# Altium schematic sheet Other_Componentt.SchDoc(Other_Componentt)
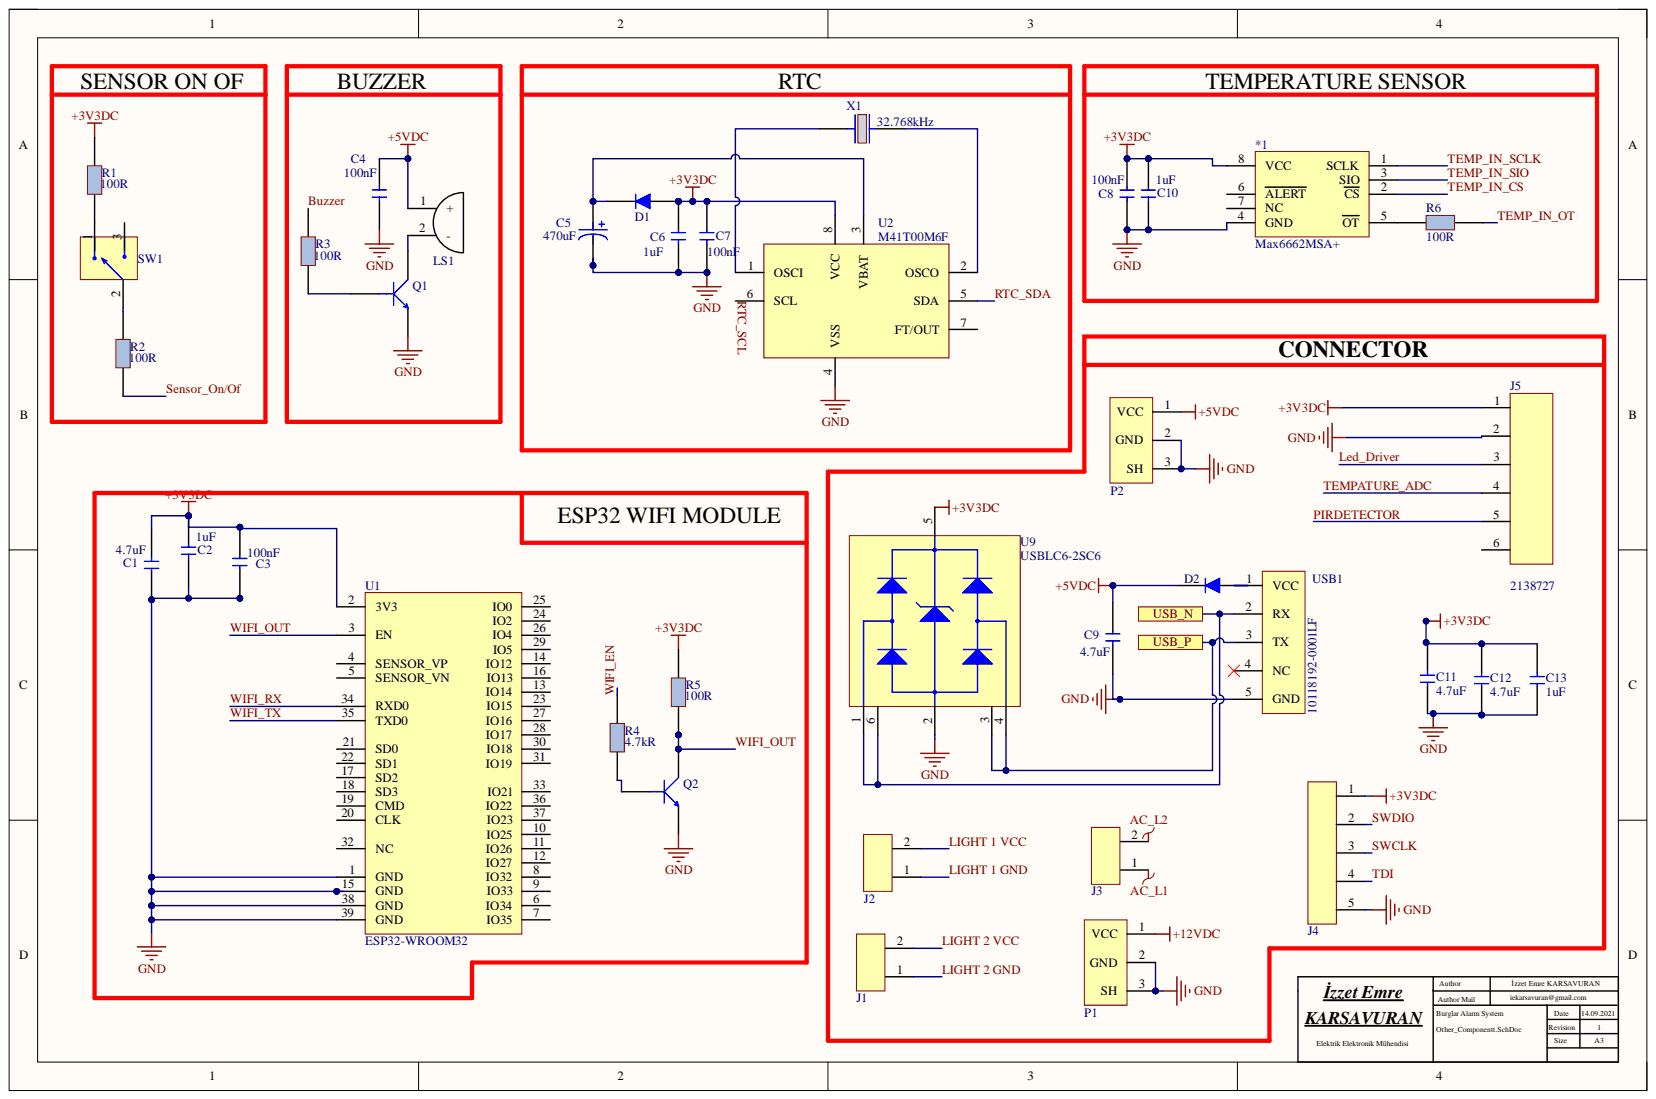
[diagram p0: Other_Componentt.SchDoc]

## Parts
*1  Max6662MSA+  [SOIC8]
C1  Capacitance: 4.7uF  [CAP_0805]  (hidden comment: CL21A475KAQNNNE)
C2  Capacitance: 1uF  [CAP_0805]  (hidden comment: CL21B105KBFNNNE)
C3  Capacitance: 100nF  [CAP_0805]  (hidden comment: CL21B104KBCNNNC)
C4  Capacitance: 100nF  [CAP_0805]  (hidden comment: CL21B104KBCNNNC)
C5  Capacitance: 470uF  [CAP_RADIAL_D10_P5]  (hidden comment: ESH477M050AH4AA)
C6  Capacitance: 1uF  [CAP_0805]  (hidden comment: CL21B105KBFNNNE)
C7  Capacitance: 100nF  [CAP_0805]  (hidden comment: CL21B104KBCNNNC)
C8  Capacitance: 100nF  [CAP_0805]  (hidden comment: CL21B104KBCNNNC)
C9  Capacitance: 4.7uF  [CAP_0805]  (hidden comment: CL21A475KAQNNNE)
C10  Capacitance: 1uF  [CAP_0805]  (hidden comment: CL21B105KBFNNNE)
C11  Capacitance: 4.7uF  [CAP_0805]  (hidden comment: CL21A475KAQNNNE)
C12  Capacitance: 4.7uF  [CAP_0805]  (hidden comment: CL21A475KAQNNNE)
C13  Capacitance: 1uF  [CAP_0805]  (hidden comment: CL21B105KBFNNNE)
D1  1N4148WS  [SOT23-F]  (value not drawn)
D2  1N4148WS  [SOT23-F]  (value not drawn)
J1  TBP01R1-508-02BE  [TBP01R1-508]  (value not drawn)
J2  TBP01R1-508-02BE  [TBP01R1-508]  (value not drawn)
J3  TBP01R1-508-02BE  [TBP01R1-508]  (value not drawn)
J4  SMH-105-02-L-S  [PINHEADER1X5_RA]  (value not drawn)
J5  Supplier Part Number 1: 2138727  [64800611622]  (hidden comment: 64800611622)
LS1  CMI-9605IC-0580T  [CMI-9605IC-0580T]  (value not drawn)
P1  PJ-037AH-SMT-TR  [JACK]  (value not drawn)
P2  PJ-037AH-SMT-TR  [JACK]  (value not drawn)
Q1  MMBT3904-7-F  [SOT23-F]  (value not drawn)
Q2  MMBT3904-7-F  [SOT23-F]  (value not drawn)
R1  Resistance: 100R  [RES_0603]  (hidden comment: RC0603JR-07100RL)
R2  Resistance: 100R  [RES_0603]  (hidden comment: RC0603JR-07100RL)
R3  Resistance: 100R  [RES_0603]  (hidden comment: RC0603JR-07100RL)
R4  Resistance: 4.7kR  [RES_0603]  (hidden comment: RC0603JR-074K7L)
R5  Resistance: 100R  [RES_0603]  (hidden comment: RC0603JR-07100RL)
R6  Resistance: 100R  [RES_0603]  (hidden comment: RC0603JR-07100RL)
SW1  EG1215AA  [EG1215AA]  (value not drawn)
U1  ESP32-WROOM32  [ESP-32]
U2  M41T00M6F  [SOIC8]
U9  USBLC6-2SC6  [SOT23-6L]
USB1  10118192-0001LF  [Micro_Usb_B]
X1  Frequency: 32.768kHz  [ABS05]  (hidden comment: ABS05-32.768KHZ-T)

## Ports
USB_N, USB_P

## Nets
+3V3DC: *1.8(VCC) C1.2 C2.1 C3.1 C6.1 C7.1 C8.1 C10.1 C11.2 C12.2 C13.2 D1.2 J4.1 J5.1 R1.2 R5.2 U1.2(3V3) U2.8(VCC) U9.5
+5VDC: C4.1 C9.1 D2.1 LS1.1 P2.1
+12VDC: P1.1
AC_L1: J3.1
AC_L2: J3.2
GND: *1.4(GND) C1.1 C2.2 C3.2 C4.2 C5.2 C6.2 C7.2 C8.2 C9.2 C10.2 C11.1 C12.1 C13.1 J4.5 J5.2 P1.2 P1.3 P2.2 P2.3 Q1.2 Q2.2 U1.1(GND) U1.15(GND) U1.38(GND) U1.39(GND) U2.4(VSS) U9.2 USB1.5(GND)
Buzzer: R3.2
Led_Driver: J5.3
LIGHT 1 GND: J2.1
LIGHT 1 VCC: J2.2
LIGHT 2 GND: J1.1
LIGHT 2 VCC: J1.2
PIRDETECTOR: J5.5
RTC_SCL: U2.6(SCL)
RTC_SDA: U2.5(SDA)
Sensor_On/Of: R2.1
SWCLK: J4.3
SWDIO: J4.2
TDI: J4.4
TEMP_IN_CS: *1.2(CS)
TEMP_IN_OT: R6.1
TEMP_IN_SCLK: *1.1(SCLK)
TEMP_IN_SIO: *1.3(SIO)
TEMPATURE_ADC: J5.4
USB_N: U9.1 U9.6 USB1.2(RX) ; port USB_N
USB_P: U9.3 U9.4 USB1.3(TX) ; port USB_P
WIFI_EN: R4.2
WIFI_OUT: Q2.3 R5.1 U1.3(EN)
WIFI_RX: U1.34(RXD0)
WIFI_TX: U1.35(TXD0)
Net*1_5: *1.5(OT) R6.2
NetC5_1: C5.1 D1.1 U2.3(VBAT)
NetD2_2: D2.2 USB1.1(VCC)
NetLS1_2: LS1.2 Q1.3
NetQ1_1: Q1.1 R3.1
NetQ2_1: Q2.1 R4.1
NetR1_1: R1.1 SW1.1
NetR2_2: R2.2 SW1.2
NetU2_1: U2.1(OSCI) X1.1
NetU2_2: U2.2(OSCO) X1.2

Not connected: *1 6(ALERT) 7(NC); J5 6; SW1 3; U1 4(SENSOR_VP) 5(SENSOR_VN) 6(IO34) 7(IO35) 8(IO32) 9(IO33) 10(IO25) 11(IO26) 12(IO27) 13(IO14) 14(IO12) 16(IO13) 17(SD2) 18(SD3) 19(CMD) 20(CLK) 21(SD0) 22(SD1) 23(IO15) 24(IO2) 25(IO0) 26(IO4) 27(IO16) 28(IO17) 29(IO5) 30(IO18) 31(IO19) 32(NC) 33(IO21) 36(IO22) 37(IO23); U2 7(FT/OUT); USB1 4(NC)

## Library data of the parts
*1 = Max6662MSA+: Temp Sensor Digital Serial (3-Wire, SPI) 8-Pin SOIC N Tube; Manufacturer: Maxim; Designed By: İzzet Emre KARSAVURAN; Max Power Dissipation: 471mW; Max Supply Voltage: 5.5V; Min Supply Voltage: 3V; Number of Bits: 12; Operating Supply Current: 600uA; Output Type: Digital,SPI; Resolution: 12b; Case/Package: SOIC; Category: Temperature and Humidity Sensors; Component Kind: Standard; ComponentLink1Description: https://datasheet.ciiva.com/5299/max6662-89142-5299456.pdf?src-supplier=Mouser; ComponentLink1URL: https://datasheet.ciiva.com/5299/max6662-89142-5299456.pdf?src-supplier=Mouser; ComponentLink2Description: https://datasheet.ciiva.com/16635/max6662-16635842.pdf?src-supplier=Avnet+Europe; ComponentLink2URL: https://datasheet.ciiva.com/16635/max6662-16635842.pdf?src-supplier=Avnet+Europe; ComponentLink3Description: https://datasheet.ciiva.com/3277/max6662-3277979.pdf?src-supplier=Avnet; ComponentLink3URL: https://datasheet.ciiva.com/3277/max6662-3277979.pdf?src-supplier=Avnet; ComponentLink4Description: https://datasheet.ciiva.com/2003/max6662-2003390.pdf?src-supplier=Digi-Key; ComponentLink4URL: https://datasheet.ciiva.com/2003/max6662-2003390.pdf?src-supplier=Digi-Key; Height: 1.5mm; Lead Free: Lead Free; Length: 5mm; Manufacturer Part Number: MAX6662MSA+; Max Operating Temperature: 150°C; Max Supply Voltage (DC): 5.5V; Min Operating Temperature: -55°C; Min Supply Voltage (DC): 3V; Number of Pins: 8; Package: SOIC8; Package Quantity: 100; Packaging: Rail/Tube; Pricing 1: 1=3,20000, 10=2,87000, 25=2,71360, 100=2,35170, 500=2,00196, 1000=1,78220 (USD); Radiation Hardening: No; REACH SVHC: Unknown; RoHS: Yes; RoHS Compliant: Yes; Series: MAX6662; Stock 1: 649; Supplier 1: Digi-Key; Supplier Part Number 1: MAX6662MSA+-ND; Width: 4mm; Interface: SPI; Library Name: burglar_alarm_system_Library.IntLib
C1 C9 C11 C12 = CAP CER 4.7uF: SAMSUNG ELECTRO-MECHANICS - CL21A475KAQNNNE - SMD Multilayer Ceramic Capacitor, 4.7 µF, 25 V, 0805 [2012 Metric], ± 10%, X5R, CL Series; Designed By: İzzet Emre KARSAVURAN; Voltage Rating: 25V; Case Code (Metric): 2012; Case Code (Imperial): 0805; Case/Package: 0805; Category: Ceramic Capacitors; Component Kind: Standard; ComponentLink1Description: https://datasheet.ciiva.com/25798/cl21a475kaqnnne-25798882.pdf?src-supplier=Digi-Key; ComponentLink1URL: https://datasheet.ciiva.com/25798/cl21a475kaqnnne-25798882.pdf?src-supplier=Digi-Key; Construction: Flat; Depth: 1.25mm; Dielectric: X5R; Height: 1.25mm; Lead Free: Lead Free; Length: 2mm; Manufacturer: Samsung; Manufacturer Part Number: CL21A475KAQNNNE; Max Operating Temperature: 85°C; Min Operating Temperature: -55°C; Mount: Surface Mount; Package Quantity: 1; Packaging: Tape and Reel; Pricing 1: 1=0,12000, 10=0,08300, 50=0,04720, 100=0,03910, 500=0,02742, 1000=0,02271 (USD); Radiation Hardening: No; RoHS: Yes; RoHS Compliant: Yes; Series: CL; Stock 1: 340871; Supplier 1: Digi-Key; Supplier Part Number 1: 1276-1244-1-ND; Thickness: 0.055inch; Tolerance: 10%; Voltage Rating (DC): 25V; Width: 0.049inch; Library Name: burglar_alarm_system_Library.IntLib
C2 C6 C10 C13 = CAP CER 1uF: SAMSUNG ELECTRO-MECHANICS - CL21B105KBFNNNE - SMD Multilayer Ceramic Capacitor, 1 µF, 50 V, 0805 [2012 Metric], ± 10%, X7R, CL Series; Designed By: İzzet Emre KARSAVURAN; Voltage: 50V; Case Code (Metric): 2012; Case Code (Imperial): 0805; Case/Package: 0805; Category: Ceramic Capacitors; Component Kind: Standard; ComponentLink1Description: https://datasheet.ciiva.com/25800/cl21b105kbfnnne-25800291.pdf?src-supplier=Digi-Key; ComponentLink1URL: https://datasheet.ciiva.com/25800/cl21b105kbfnnne-25800291.pdf?src-supplier=Digi-Key; ComponentLink2Description: https://datasheet.ciiva.com/16449/cl21b105kbfnnne-16449003.pdf?src-supplier=Future+Electronics; ComponentLink2URL: https://datasheet.ciiva.com/16449/cl21b105kbfnnne-16449003.pdf?src-supplier=Future+Electronics; ComponentLink3Description: https://datasheet.ciiva.com/26838/getdatasheetpartid-354075-26838668.pdf?src-supplier=Verical; ComponentLink3URL: https://datasheet.ciiva.com/26838/getdatasheetpartid-354075-26838668.pdf?src-supplier=Verical; Construction: Flat; Depth: 1.25mm; Dielectric: X7R; Height: 1.25mm; Length: 2mm; Manufacturer: Samsung; Manufacturer Part Number: CL21B105KBFNNNE; Max Operating Temperature: 125°C; Min Operating Temperature: -55°C; Mount: Surface Mount; Package Quantity: 1; Packaging: Tape and Reel; Pricing 1: 1=0,13000, 10=0,09000, 50=0,05120, 100=0,04250, 500=0,02978, 1000=0,02465 (USD); Radiation Hardening: No; RoHS: Yes; RoHS Compliant: Yes; Series: CL; Supplier 1: Digi-Key; Supplier Part Number 1: 1276-1029-6-ND; Thickness: 0.053inch; Tolerance: 10%; Voltage Rating: 50V; Width: 0.049inch; Library Name: burglar_alarm_system_Library.IntLib
C3 C4 C7 C8 = CAP CER 100nF-0803: Samsung Electro-Mechanics 100nF Multilayer Ceramic Capacitor (MLCC) 50 V 10% X7R dielectric CL max op. temp. +125C; Designed By: İzzet Emre KARSAVURAN; Voltage: 50V; Case Code (Metric): 2012; Case Code (Imperial): 0805; Case/Package: 0805; Category: Ceramic Capacitors; Component Kind: Standard; ComponentLink1Description: https://datasheet.ciiva.com/25710/cl21b104kbcnnnc-25710182.pdf?src-supplier=Digi-Key; ComponentLink1URL: https://datasheet.ciiva.com/25710/cl21b104kbcnnnc-25710182.pdf?src-supplier=Digi-Key; ComponentLink2Description: https://datasheet.ciiva.com/29199/454152-da-01-en-vs-kondensator-0805-29199814.pdf?src-supplier=Conrad; ComponentLink2URL: https://datasheet.ciiva.com/29199/454152-da-01-en-vs-kondensator-0805-29199814.pdf?src-supplier=Conrad; Construction: Flat; Contact Plating: Tin; Depth: 1.25mm; Dielectric: X7R; Height: 0.85mm; Lead Free: Lead Free; Length: 2mm; Manufacturer: Samsung; Manufacturer Part Number: CL21B104KBCNNNC; Material: Ceramic; Max Operating Temperature: 125°C; Min Operating Temperature: -55°C; Mount: Surface Mount; Packaging: Tape and Reel; Pricing 1: 1=0,10000, 10=0,05000, 50=0,02740, 100=0,02240, 500=0,01598, 1000=0,01256 (USD); Radiation Hardening: No; RoHS: Yes; RoHS Compliant: Yes; Series: CL; Supplier 1: Digi-Key; Supplier Part Number 1: 1276-1003-6-ND; Thickness: 0.037inch; Tolerance: 10%; Voltage Rating: 50V; Voltage Rating (DC): 50V; Width: 0.049inch; Library Name: burglar_alarm_system_Library.IntLib
C5 = CAP ALU ELEC 470uF 50V: Cap, 470UF, 50V, Alu Elec, Radial; Manufacturer: KEMET; Designed By: İzzet Emre KARSAVURAN; Life (Hours): 2000Hour; Polarity: Polar; Tolerance: 20%; Case/Package: Radial; Component Kind: Standard; ComponentLink1Description: https://api.kemet.com/component-edge/download/datasheet/ESH477M050AH4AA.pdf?src-supplier=Digi-Key; ComponentLink1URL: https://api.kemet.com/component-edge/download/datasheet/ESH477M050AH4AA.pdf?src-supplier=Digi-Key; Manufacturer Part Number: ESH477M050AH4AA; Max Operating Temperature: 105°C; Min Operating Temperature: -40°C; Mount: Through Hole; Packaging: Bulk; Pricing 1: 1=0,59000, 10=0,41700, 50=0,31540, 100=0,27470, 500=0,20350, 1000=0,17298, 2500=0,16280, 5000=0,15262 (USD); RoHS: Yes; RoHS Compliant: No; Supplier 1: Digi-Key; Supplier Part Number 1: 399-15683-ND; Voltage Rating: 50V; Library Name: burglar_alarm_system_Library.IntLib
D1 D2 = 1N4148WS: ON SEMICONDUCTOR - 1N4148WS - Small Signal Diode, Single, 75 V, 150 mA, 1 V, 4 ns; Manufacturer: ON Semiconductor / Fairchild; Average Rectified Current: 150mA; Designed By: İzzet Emre KARSAVURAN; Forward Voltage: 1V; Max Forward Surge Current (Ifsm): 2A; Max Repetitive Reverse Voltage (Vrrm): 75V; Max Reverse Current: 5uA; Power Dissipation: 200mW; Recovery Time: 4ns; Case/Package: SOC323F; Category: Rectifier Diodes; Component Kind: Standard; ComponentLink1Description: https://datasheet.ciiva.com/25968/1n914bws-25968945.pdf?src-supplier=Avnet+Europe; ComponentLink1URL: https://datasheet.ciiva.com/25968/1n914bws-25968945.pdf?src-supplier=Avnet+Europe; ComponentLink2Description: https://datasheet.ciiva.com/30479/0900766b810e1cd3-30479206.pdf?src-supplier=RSComponents; ComponentLink2URL: https://datasheet.ciiva.com/30479/0900766b810e1cd3-30479206.pdf?src-supplier=RSComponents; ComponentLink3Description: https://datasheet.ciiva.com/8300/1n914bws-8300360.pdf?src-supplier=Avnet; ComponentLink3URL: https://datasheet.ciiva.com/8300/1n914bws-8300360.pdf?src-supplier=Avnet; ComponentLink4Description: https://datasheet.ciiva.com/15208/getdatasheetpartid-127819-15208358.pdf?src-supplier=Verical; ComponentLink4URL: https://datasheet.ciiva.com/15208/getdatasheetpartid-127819-15208358.pdf?src-supplier=Verical; ComponentLink5Description: https://datasheet.ciiva.com/910/1n4148ws-910381.pdf?src-supplier=Digi-Key; ComponentLink5URL: https://datasheet.ciiva.com/910/1n4148ws-910381.pdf?src-supplier=Digi-Key; ComponentLink6Description: https://datasheet.ciiva.com/910/1n4148ws-910382.pdf?src-supplier=Digi-Key; ComponentLink6URL: https://datasheet.ciiva.com/910/1n4148ws-910382.pdf?src-supplier=Digi-Key; ComponentLink7Description: https://datasheet.ciiva.com/910/1n4148ws-910380.pdf?src-supplier=Digi-Key; ComponentLink7URL: https://datasheet.ciiva.com/910/1n4148ws-910380.pdf?src-supplier=Digi-Key; Contact Plating: Tin,Matte; Element Configuration: Single; Forward Current: 150mA; Height: 1mm; Lead Free: Lead Free; Length: 1.8mm; Manufacturer Part Number: 1N4148WS; Max Operating Temperature: 150°C; Max Reverse Voltage (DC): 75V; Min Operating Temperature: -55°C; Mount: Surface Mount; Number of Pins: 2; Package Quantity: 1; Packaging: Tape and Reel; Pricing 1: 1=0,21000, 10=0,19200, 100=0,10460, 500=0,06436, 1000=0,04388 (USD); Radiation Hardening: No; REACH SVHC: No SVHC; Reverse Recovery Time: 4ns; Reverse Voltage: 75V; RoHS: Yes; RoHS Compliant: Yes; Series: -; Supplier 1: Digi-Key; Supplier Part Number 1: 1N4148WSFSCT-ND; Weight: 0.0276g; Width: 1.35mm; Library Name: burglar_alarm_system_Library.IntLib
J1-J3 = TBP01R1-508-02BE: Conn Shrouded Header HDR 2 POS 5.08mm Solder RA Side Entry Thru-Hole Bag; Manufacturer: CUI; Designed By: İzzet Emre KARSAVURAN; Case/Package: TBP01R1-508; Component Kind: Standard; Manufacturer Part Number: TBP01R1-508-02BE; RoHS: Yes; Supplier 1: Future Electronics; Supplier Part Number 1: 1117528; Library Name: burglar_alarm_system_Library.IntLib
J4 = SMH-105-02-L-S: .100 C.L. HORIZONTAL SMT SOCKET; Manufacturer: Samtec; Contact Resistance: 10mR; Designed By: İzzet Emre KARSAVURAN; Gender: Receptacle; Number of Contacts: 4; Pitch: 2.54mm; Case/Package: PINHEADER1X5_RA; Category: Headers and Wire Housings; Component Kind: Standard; ComponentLink1Description: http://components.arrow.com/part/dataview/0323_04802604?src-supplier=Arrow+Europe; ComponentLink1URL: http://components.arrow.com/part/dataview/0323_04802604?src-supplier=Arrow+Europe; ComponentLink2Description: http://www.farnell.com/datasheets/1927708.pdf?_ga=2.237598468.569325269.1503285096-1413671594.1503025027&src-supplier=Farnell; ComponentLink2URL: http://www.farnell.com/datasheets/1927708.pdf?_ga=2.237598468.569325269.1503285096-1413671594.1503025027&src-supplier=Farnell; ComponentLink3Description: ftp://ftp.samtec.com/webfiles/PDF/SMH.PDF?src-supplier=Avnet+Europe; ComponentLink3URL: ftp://ftp.samtec.com/webfiles/PDF/SMH.PDF?src-supplier=Avnet+Europe; ComponentLink4Description: http://www.samtec.com/documents/webfiles/pdf/SMH.PDF?src-supplier=Newark; ComponentLink4URL: http://www.samtec.com/documents/webfiles/pdf/SMH.PDF?src-supplier=Newark; ComponentLink5Description: http://www.samtec.com/documents/webfiles/pdf/SMH.PDF?src-supplier=Avnet; ComponentLink5URL: http://www.samtec.com/documents/webfiles/pdf/SMH.PDF?src-supplier=Avnet; ComponentLink6Description: http://suddendocs.samtec.com/catalog_english/smh.pdf?src-supplier=Digi-Key; ComponentLink6URL: http://suddendocs.samtec.com/catalog_english/smh.pdf?src-supplier=Digi-Key; Contact Material: Bronze; Contact Plating: Gold; Depth: 10.8mm; Housing Color: Black; Housing Material: Polymer; Length: 13.21mm; Manufacturer Part Number: SMH-105-02-L-S; Max Operating Temperature: 125°C; Min Operating Temperature: -55°C; Number of Rows: 1; Orientation: Horizontal; Packaging: Rail/Tube; Pricing 1: 1=2,01000, 10=1,75200, 80=1,56550, 440=1,30464, 945=1,11825, 4900=0,99400 (USD); Radiation Hardening: No; REACH SVHC: No SVHC; RoHS: Yes; Supplier 1: Digi-Key; Supplier Part Number 1: SAM11813-ND; Termination: Solder; Library Name: burglar_alarm_system_Library.IntLib
J5 = 64800611622_conn_6pin: Manufacturer: Wurth Electronics; Designed By: İzzet Emre KARSAVURAN; Component Kind: Standard; Manufacturer Part Number: 64800611622; Pricing 1: 10=0,39600, 50=0,36600, 200=0,34000, 500=0,31800 (GBP); RoHS: No; Stock 1: 160; Supplier 1: RSComponents; Library Name: burglar_alarm_system_Library.IntLib
LS1 = CMI-9605IC-0580T: Buzzers Indicator, Internally Driven Magnetic 5 V 30mA 2.7kHz 80dB @ 5V, 10cm Through Hole PC Pins; Designed By: İzzet Emre Karsavuran; Max Supply Voltage: 7; Min Supply Voltage: 3; rated frequency  typ: 2,700; rated frequency max: 2,800; rated frequency min: 2,600; Case/Package: CMI-9605IC-0580T; Component Kind: Standard; ComponentLink1Description: Link; ComponentLink1URL: https://www.cuidevices.com/product/resource/cmi-9605ic-0580t.pdf; Max Operating Temperature: 85; Min Operating Temperature: -40; Library Name: burglar_alarm_system_Library.IntLib
P1 P2 = PJ-037AH-SMT-TR: DC Power Connectors Power Jacks; Manufacturer: CUI; Current Rating: 5A; Designed By: İzzet Emre KARSAVURAN; Gender: Male; Number of Conductors: 2; Shielding: Unshielded; Voltage Rating: 24V; Insulation Resistance: 100MR; Case/Package: SMD/SMT; Category: Connectors; Color: Black; Component Kind: Standard; ComponentLink1Description: http://www.mouser.com/ds/2/670/pj-037ah-smt-516065.pdf?src-supplier=Mouser; ComponentLink1URL: http://www.mouser.com/ds/2/670/pj-037ah-smt-516065.pdf?src-supplier=Mouser; ComponentLink2Description: https://www.cui.com/product/resource/digikeypdf/pj-037ah-smt-tr.pdf?src-supplier=Digi-Key; ComponentLink2URL: https://www.cui.com/product/resource/digikeypdf/pj-037ah-smt-tr.pdf?src-supplier=Digi-Key; ComponentLink3Description: http://www.cui.com/product/resource/digikeypdf/pj-037ah-smt.pdf?src-supplier=Digi-Key; ComponentLink3URL: http://www.cui.com/product/resource/digikeypdf/pj-037ah-smt.pdf?src-supplier=Digi-Key; Connector Type: Jack; Contact Gender: Male; Contact Material: Copper; Contact Plating: Nickel; Flammability Rating: UL94 V-0; Housing Color: Black; Housing Material: Thermoplastic; Manufacturer Part Number: PJ-037AH-SMT-TR; Max Operating Temperature: 85°C; Min Operating Temperature: -25°C; Mount: Surface Mount,Through Hole; Orientation: Horizontal; Package Quantity: 200; Packaging: Tape and Reel; RoHS: Yes; Supplier 1: Digi-Key; Supplier Part Number 1: CP-037AHPJCT-ND; Termination: Solder; Library Name: burglar_alarm_system_Library.IntLib
Q1 Q2 = MMBT3904-7-F: TRANS NPN 40V 0.2A SMD SOT23-3; Manufacturer: Diodes Zetex; Collector Base Voltage (VCBO): 60V; Collector Emitter Saturation Voltage: 300mV; Continuous Collector Current: 200mA; Designed By: İzzet Emre KARSAVURAN; Element Configuration: Single; Emitter Base Voltage (VEBO): 6V; Frequency: 300MHz; hFE Min: 100; Max Power Dissipation: 300mW; Number of Elements: 1; Power Dissipation: 350mW; Case/Package: SOT-23; Category: BJTs; Collector Emitter Breakdown Voltage: 40V; Collector Emitter Voltage (VCEO): 40V; Collector-emitter Voltage-Max: 300mV; Component Kind: Standard; ComponentLink1Description: https://datasheet.ciiva.com/11201/ds30036-11201778.pdf?src-supplier=Avnet; ComponentLink1URL: https://datasheet.ciiva.com/11201/ds30036-11201778.pdf?src-supplier=Avnet; ComponentLink2Description: https://datasheet.ciiva.com/15138/getdatasheetpartid-53265-15138780.pdf?src-supplier=Verical; ComponentLink2URL: https://datasheet.ciiva.com/15138/getdatasheetpartid-53265-15138780.pdf?src-supplier=Verical; ComponentLink3Description: https://datasheet.ciiva.com/22711/1915516-22711750.pdf?src-supplier=Element14; ComponentLink3URL: https://datasheet.ciiva.com/22711/1915516-22711750.pdf?src-supplier=Element14; ComponentLink4Description: https://datasheet.ciiva.com/11198/ds30036-11198999.pdf?src-supplier=Avnet; ComponentLink4URL: https://datasheet.ciiva.com/11198/ds30036-11198999.pdf?src-supplier=Avnet; ComponentLink5Description: https://datasheet.ciiva.com/6361/ds30036-6361256.pdf?src-supplier=Digi-Key; ComponentLink5URL: https://datasheet.ciiva.com/6361/ds30036-6361256.pdf?src-supplier=Digi-Key; ComponentLink6Description: https://datasheet.ciiva.com/6361/ds30036-6361254.pdf?src-supplier=Digi-Key; ComponentLink6URL: https://datasheet.ciiva.com/6361/ds30036-6361254.pdf?src-supplier=Digi-Key; Contact Plating: Tin,Matte; Gain Bandwidth Product: 300MHz; Height: 1mm; Lead Free: Lead Free; Length: 3.05mm; Manufacturer Part Number: MMBT3904-7-F; Max Breakdown Voltage: 40V; Max Collector Current: 200mA; Max Frequency: 300MHz; Max Operating Temperature: 150°C; Min Operating Temperature: -55°C; Mount: Surface Mount; Number of Pins: 3; Package Quantity: 3000; Packaging: Tape and Reel; Polarity: NPN; Pricing 1: 1=0,13000, 10=0,11700, 100=0,06370, 500=0,03918, 1000=0,02671 (USD); Radiation Hardening: No; REACH SVHC: No SVHC; RoHS: Yes; RoHS Compliant: Yes; Series: -; Supplier 1: Digi-Key; Supplier Part Number 1: MMBT3904-FDIDKR-ND; Transition Frequency: 300MHz; Voltage Rating (DC): 40V; Weight: 0.000282oz; Width: 1.4mm; Library Name: burglar_alarm_system_Library.IntLib
R1-R3 R5 R6 = RES 100 OHM: Res Thick Film 0603 100 Ohm 5% 0.1W(1/10W) ±100ppm/C Molded SMD Paper T/R; Manufacturer: Yageo; Designed By: İzzet Emre KARSAVURAN; Max Power Dissipation: 100mW; Temperature Coefficient: 100ppm/°C; Voltage Rating: 50V; Case Code (Metric): 1608; Case Code (Imperial): 0603; Case/Package: 0603; Category: Chip SMD Resistors; Component Kind: Standard; ComponentLink1Description: https://datasheet.ciiva.com/7746/443971-7746425.pdf?src-supplier=Element14; ComponentLink1URL: https://datasheet.ciiva.com/7746/443971-7746425.pdf?src-supplier=Element14; ComponentLink2Description: https://datasheet.ciiva.com/7746/443971-7746426.pdf?src-supplier=Element14; ComponentLink2URL: https://datasheet.ciiva.com/7746/443971-7746426.pdf?src-supplier=Element14; ComponentLink3Description: https://datasheet.ciiva.com/8883/pyu-rc0603-51-rohs-l-4-8883047.pdf?src-supplier=Avnet; ComponentLink3URL: https://datasheet.ciiva.com/8883/pyu-rc0603-51-rohs-l-4-8883047.pdf?src-supplier=Avnet; ComponentLink4Description: https://datasheet.ciiva.com/15189/getdatasheetpartid-325207-15189846.pdf?src-supplier=Verical; ComponentLink4URL: https://datasheet.ciiva.com/15189/getdatasheetpartid-325207-15189846.pdf?src-supplier=Verical; Construction: Rectangular; Contact Plating: Tin,Matte; Depth: 0.8mm; Features: Moisture Resistant; Lead Free: Lead Free; Length: 1.6mm; Manufacturer Part Number: RC0603JR-07100RL; Max Operating Temperature: 155°C; Military Standard: Not; Min Operating Temperature: -55°C; Number of Pins: 2; Number of Terminations: 2; Packaging: Tape and Reel; Power Rating: 100mW; Pricing 1: 1=0,10000, 10=0,02000, 100=0,00800, 1000=0,00360, 2500=0,00312 (USD); Radiation Hardening: No; REACH SVHC: Unknown; Resistance Tolerance: 5%; Resistor Type: Thick Film; RoHS: Yes; RoHS Compliant: Yes; Series: RC; Size Code: 0603; Stock 1: 1295481; Supplier 1: Digi-Key; Supplier Part Number 1: 311-100GRCT-ND; Termination: SMD/SMT; Tolerance: 5%; Voltage Rating (DC): 50V; Width: 0.8mm; Library Name: burglar_alarm_system_Library.IntLib
R4 = RES 47k OHM: Res Thick Film 0603 4.7K Ohm 5% 0.1W(1/10W) ±100ppm/C Molded SMD Paper T/R; Manufacturer: Yageo; Designed By: İzzet Emre KARSAVURAN; Max Power Dissipation: 100mW; Temperature Coefficient: 100ppm/°C; Voltage Rating: 50V; Case Code (Metric): 1608; Case Code (Imperial): 0603; Case/Package: 0603; Category: Chip SMD Resistors; Component Kind: Standard; ComponentLink1Description: https://datasheet.ciiva.com/46412/443971-46412858.pdf?src-supplier=Element14; ComponentLink1URL: https://datasheet.ciiva.com/46412/443971-46412858.pdf?src-supplier=Element14; Construction: Rectangular; Depth: 0.8mm; Features: Moisture Resistant; Lead Free: Lead Free; Length: 1.6mm; Manufacturer Part Number: RC0603JR-074K7L; Max Operating Temperature: 155°C; Military Standard: Not; Min Operating Temperature: -55°C; Number of Pins: 2; Number of Terminations: 2; Packaging: Tape and Reel; Power Rating: 100mW; Pricing 1: 1=0,10000, 10=0,02000, 100=0,00800, 1000=0,00360, 2500=0,00312 (USD); REACH SVHC: No SVHC; Resistance Tolerance: 5%; Resistor Type: Thick Film; RoHS: Yes; RoHS Compliant: Yes; Series: RC; Size Code: 0603; Stock 1: 1969134; Supplier 1: Digi-Key; Supplier Part Number 1: 311-4.7KGRCT-ND; Termination: SMD/SMT; Tolerance: 5%; Voltage Rating (DC): 50V; Width: 0.8mm; Library Name: burglar_alarm_system_Library.IntLib
SW1 = EG1215AA: Slide Switch, SPDT, 0.025A, 24V, SMD; Manufacturer: E-Switch; Actuator Length: 1.4mm; Actuator Type: Standard; Current Rating: 25mA; Designed By: İzzet Emre KARSAVURAN; Electrical Life: 10000Cycles; Max Power Dissipation: 5W; Operating Force: 150gf; Switch Function: On-On; Switch Travel: 1.5mm; Tolerance: 4.95%; Zener Voltage: 9.1V; Impedance: 2R; Case/Package: Axial; Component Kind: Standard; ComponentLink1Description: https://media.digikey.com/pdf/Data%20Sheets/E-Switch%20PDFs/EG1215_Series_Ds.pdf?src-supplier=Digi-Key; ComponentLink1URL: https://media.digikey.com/pdf/Data%20Sheets/E-Switch%20PDFs/EG1215_Series_Ds.pdf?src-supplier=Digi-Key; ComponentLink2Description: http://www.farnell.com/datasheets/2645007.pdf?src-supplier=Farnell; ComponentLink2URL: http://www.farnell.com/datasheets/2645007.pdf?src-supplier=Farnell; Manufacturer Part Number: EG1215AA; Max Operating Temperature: 175°C; Mechanical Life: 10000Cycles; Min Operating Temperature: -20°C; Mount: Surface Mount,Through Hole; Package: EG1215AA; Packaging: Cut Tape; Pricing 1: 1=0,99000, 10=0,95100, 25=0,88840, 100=0,77150, 250=0,70200, 500=0,65080, 1000=0,60327 (USD); RoHS: Yes; Stock 1: 3829; Supplier 1: Digi-Key; Supplier Part Number 1: EG5720CT-ND; Termination: Gull Wing; Throw Configuration: SPDT; Voltage Rating (DC): 24V; Library Name: burglar_alarm_system_Library.IntLib
U1 = ESP32-WROOM32: • ESP32-D0WD-V3 embedded, Xtensa® dual-core  32-bit LX6 microprocessor, up to 240 MHz  • 448 KB ROM for booting and core functions  • 520 KB SRAM for data and instructions  • 16 KB SRAM in RTC; Manufacturer: Espressif Systems; Manufacturer Part Number: ESP32-WROOM-32E(4MB); Designed By: İzzet Emre Karsavuran; Max Supply Voltage: 3.6V; Min Supply Voltage: 3V; Case/Package: ESP32; Component Kind: Standard; ComponentLink1Description: datasheed; ComponentLink1URL: https://www.espressif.com/sites/default/files/documentation/esp32-wroom-32e_esp32-wroom-32ue_datasheet_en.pdf; Max Operating Temperature: 85; Min Operating Temperature: -40; Pricing 1: 1=3,00000 (USD); RoHS: No; Supplier 1: Digi-Key; Library Name: burglar_alarm_system_Library.IntLib
U2 = M41T00M6F: IC RTC CLK/CALENDAR I2C 8-SOIC; Manufacturer: STMicroelectronics; Date Format: YY-MM-DD-dd; Designed By: İzzet Emre Karsavuran; Logic Function: Clock; Max Supply Voltage: 5.5V; Memory Size: 8B; Min Supply Voltage: 2V; Time Format: HH:MM:SS; Interface: I2C,Serial,2-Wire; Case/Package: SOIC; Category: Memory; Component Kind: Standard; ComponentLink1Description: https://datasheet.ciiva.com/25889/cd00001542-25889923.pdf?src-supplier=Avnet+Europe; ComponentLink1URL: https://datasheet.ciiva.com/25889/cd00001542-25889923.pdf?src-supplier=Avnet+Europe; ComponentLink2Description: https://datasheet.ciiva.com/16213/cd00001542-16213654.pdf?src-supplier=Future+Electronics; ComponentLink2URL: https://datasheet.ciiva.com/16213/cd00001542-16213654.pdf?src-supplier=Future+Electronics; ComponentLink3Description: New link; ComponentLink4Description: https://datasheet.ciiva.com/7630/1837112-7630077.pdf?src-supplier=Element14; ComponentLink4URL: https://datasheet.ciiva.com/7630/1837112-7630077.pdf?src-supplier=Element14; ComponentLink5Description: https://datasheet.ciiva.com/41459/001185345-da-01-en-ic-rtc-srl-512kbit-m41t00m6f-soic-8-stm-41459818.pdf?src-supplier=Conrad; ComponentLink5URL: https://datasheet.ciiva.com/41459/001185345-da-01-en-ic-rtc-srl-512kbit-m41t00m6f-soic-8-stm-41459818.pdf?src-supplier=Conrad; Height: 1.5mm; Lead Free: Lead Free; Length: 5mm; Manufacturer Part Number: M41T00M6F; Max Operating Temperature: 85°C; Min Operating Temperature: -40°C; Mount: Surface Mount; Non-volatile Memory Size: 8B; Number of Pins: 8; Package: SOIC8; Package Quantity: 2500; Packaging: Tape and Reel; Pricing 1: 1=2,95000, 10=2,64900, 25=2,49880, 100=2,12890, 250=1,99896, 500=1,74908, 1000=1,65008 (USD); Radiation Hardening: No; RAM Size: 8B; REACH SVHC: No SVHC; RoHS: Yes; RoHS Compliant: Yes; Series: M41T00; Supplier 1: Digi-Key; Supply Voltage-Nom: 5.5V; Temperature Grade: INDUSTRIAL; Weight: 0.019048oz; Width: 4mm; Library Name: burglar_alarm_system_Library.IntLib
U9 = USB PROTECTION: TVS DIODE 5.25V 17V SOT23-6; Manufacturer: STMicroelectronics; Breakdown Voltage: 6V; Capacitance: 3.5pF; Data Rate: 480Mbps; Designed By: İzzet Emre KARSAVURAN; Direction: Unidirectional,Bidirectional; Element Configuration: Dual; ESD Protection: Yes; Leakage Current: 150nA; Number of Bidirectional Channels: 2; Number of Elements: 1; Operating Supply Voltage: 1.1V; Peak Pulse Current: 5A; Test Current: 1mA; Working Voltage: 5V; Case/Package: SOT-23; Category: TVS Diodes; Clamping Voltage: 17V; Clamping Voltage-Max: 17V; Component Kind: Standard; ComponentLink1Description: https://datasheet.ciiva.com/1268/1690354-1268384.pdf?src-supplier=Element14; ComponentLink1URL: https://datasheet.ciiva.com/1268/1690354-1268384.pdf?src-supplier=Element14; ComponentLink2Description: https://datasheet.ciiva.com/4508/cd00050750-113117-4508433.pdf?src-supplier=Mouser; ComponentLink2URL: https://datasheet.ciiva.com/4508/cd00050750-113117-4508433.pdf?src-supplier=Mouser; ComponentLink3Description: https://datasheet.ciiva.com/15143/getdatasheetpartid-148465-15143789.pdf?src-supplier=Verical; ComponentLink3URL: https://datasheet.ciiva.com/15143/getdatasheetpartid-148465-15143789.pdf?src-supplier=Verical; ComponentLink4Description: https://datasheet.ciiva.com/26787/usblc6-2sc6-26787908.pdf?src-supplier=IIIC; ComponentLink4URL: https://datasheet.ciiva.com/26787/usblc6-2sc6-26787908.pdf?src-supplier=IIIC; ComponentLink5Description: https://datasheet.ciiva.com/16200/cd00050750-16200026.pdf?src-supplier=Future+Electronics; ComponentLink5URL: https://datasheet.ciiva.com/16200/cd00050750-16200026.pdf?src-supplier=Future+Electronics; ComponentLink6Description: https://datasheet.ciiva.com/41444/001184109-da-01-en-ic-esd-protecti-usblc6-2sc6-sot-23-6-stm-41444156.pdf?src-supplier=Conrad; ComponentLink6URL: https://datasheet.ciiva.com/41444/001184109-da-01-en-ic-esd-protecti-usblc6-2sc6-sot-23-6-stm-41444156.pdf?src-supplier=Conrad; Contact Plating: Tin,Matte; Depth: 1.75mm; Height: 1.3mm; Lead Free: Lead Free; Length: 3.05mm; Manufacturer Part Number: USBLC6-2SC6; Max Breakdown Voltage: 6V; Max Operating Temperature: 125°C; Max Reverse Leakage Current: 150nA; Min Breakdown Voltage: 6V; Min Operating Temperature: -40°C; Mount: Surface Mount; Number of Channels: 2; Number of Pins: 6; Package Quantity: 3000; Packaging: Tape and Reel; Polarity: Unidirectional,Bidirectional; Power Line Protection: Yes; Pricing 1: 1=0,52000, 10=0,44800, 100=0,33450, 500=0,26282, 1000=0,20308 (USD); Radiation Hardening: No; REACH SVHC: No SVHC; Reverse Breakdown Voltage: 6V; Reverse Standoff Voltage: 5.25V; RoHS: Yes; RoHS Compliant: Yes; Series: USB; Supplier 1: Digi-Key; Supplier Part Number 1: 497-5235-6-ND; Termination: SMD/SMT; Width: 1.75mm; Library Name: burglar_alarm_system_Library.IntLib
USB1 = Micro B USB Connector: CONN USB MICRO B RECPT SMT R/A; Manufacturer: Amphenol ICC / FCI; Designed By: İzzet Emre KARSAVURAN; Case/Package: Micro_Usb_B; Category: USB Connectors; Component Kind: Standard; ComponentLink1Description: http://components.arrow.com/part/dataview/0323_04107371?src-supplier=Arrow+Europe; ComponentLink1URL: http://components.arrow.com/part/dataview/0323_04107371?src-supplier=Arrow+Europe; ComponentLink2Description: http://uk.rs-online.com/webdocs/1450/0900766b814507ee.pdf?src-supplier=RSComponents; ComponentLink2URL: http://uk.rs-online.com/webdocs/1450/0900766b814507ee.pdf?src-supplier=RSComponents; ComponentLink3Description: https://cdn.amphenol-icc.com/media/wysiwyg/files/documentation/datasheet/inputoutput/io_usb_micro.pdf?src-supplier=Avnet; ComponentLink3URL: https://cdn.amphenol-icc.com/media/wysiwyg/files/documentation/datasheet/inputoutput/io_usb_micro.pdf?src-supplier=Avnet; ComponentLink4Description: https://cdn.amphenol-icc.com/media/wysiwyg/files/documentation/datasheet/inputoutput/io_usb_micro.pdf?src-supplier=Arrow+Electronics; ComponentLink4URL: https://cdn.amphenol-icc.com/media/wysiwyg/files/documentation/datasheet/inputoutput/io_usb_micro.pdf?src-supplier=Arrow+Electronics; ComponentLink5Description: https://cdn.amphenol-icc.com/media/wysiwyg/files/documentation/datasheet/inputoutput/io_usb_micro.pdf?src-supplier=Verical; ComponentLink5URL: https://cdn.amphenol-icc.com/media/wysiwyg/files/documentation/datasheet/inputoutput/io_usb_micro.pdf?src-supplier=Verical; ComponentLink6Description: http://portal.fciconnect.com/Comergent//fci/drawing/10118192.pdf?src-supplier=Avnet+Asia; ComponentLink6URL: http://portal.fciconnect.com/Comergent//fci/drawing/10118192.pdf?src-supplier=Avnet+Asia; ComponentLink7Description: https://cdn.amphenol-icc.com/media/wysiwyg/files/documentation/datasheet/inputoutput/io_usb_micro.pdf?src-supplier=Allied+Electronics; ComponentLink7URL: https://cdn.amphenol-icc.com/media/wysiwyg/files/documentation/datasheet/inputoutput/io_usb_micro.pdf?src-supplier=Allied+Electronics; ComponentLink8Description: http://www.farnell.com/datasheets/2366786.pdf?src-supplier=Newark; ComponentLink8URL: http://www.farnell.com/datasheets/2366786.pdf?src-supplier=Newark; ComponentLink9Description: https://cdn.amphenol-icc.com/media/wysiwyg/files/documentation/datasheet/inputoutput/io_usb_micro.pdf?src-supplier=Mouser; ComponentLink9URL: https://cdn.amphenol-icc.com/media/wysiwyg/files/documentation/datasheet/inputoutput/io_usb_micro.pdf?src-supplier=Mouser; ComponentLink10Description: http://www.amphenol-icc.com/media/wysiwyg/files/drawing/10118192.pdf?src-supplier=Digi-Key; ComponentLink10URL: http://www.amphenol-icc.com/media/wysiwyg/files/drawing/10118192.pdf?src-supplier=Digi-Key; ComponentLink11Description: http://www.farnell.com/cad/1935767.pdf?src-supplier=Element14; ComponentLink11URL: http://www.farnell.com/cad/1935767.pdf?src-supplier=Element14; ComponentLink12Description: http://www.farnell.com/datasheets/2366786.pdf?src-supplier=Farnell; ComponentLink12URL: http://www.farnell.com/datasheets/2366786.pdf?src-supplier=Farnell; ComponentLink13Description: https://cdn.amphenol-icc.com/media/wysiwyg/files/documentation/datasheet/inputoutput/io_usb_micro.pdf?src-supplier=TME; ComponentLink13URL: https://cdn.amphenol-icc.com/media/wysiwyg/files/documentation/datasheet/inputoutput/io_usb_micro.pdf?src-supplier=TME; ComponentLink14Description: https://cdn.amphenol-icc.com/media/wysiwyg/files/documentation/datasheet/inputoutput/io_usb_micro.pdf?src-supplier=TTI; ComponentLink14URL: https://cdn.amphenol-icc.com/media/wysiwyg/files/documentation/datasheet/inputoutput/io_usb_micro.pdf?src-supplier=TTI; ComponentLink15Description: https://cdn.amphenol-icc.com/media/wysiwyg/files/documentation/datasheet/inputoutput/io_usb_micro.pdf?src-supplier=NAC+Semi; ComponentLink15URL: https://cdn.amphenol-icc.com/media/wysiwyg/files/documentation/datasheet/inputoutput/io_usb_micro.pdf?src-supplier=NAC+Semi; ComponentLink16Description: https://cdn.amphenol-icc.com/media/wysiwyg/files/documentation/datasheet/inputoutput/io_usb_micro.pdf?src-supplier=SOS+electronic; ComponentLink16URL: https://cdn.amphenol-icc.com/media/wysiwyg/files/documentation/datasheet/inputoutput/io_usb_micro.pdf?src-supplier=SOS+electronic; ComponentLink17Description: https://cdn.amphenol-icc.com/media/wysiwyg/files/documentation/datasheet/inputoutput/io_usb_micro.pdf?src-supplier=Freelance+Electronics; ComponentLink17URL: https://cdn.amphenol-icc.com/media/wysiwyg/files/documentation/datasheet/inputoutput/io_usb_micro.pdf?src-supplier=Freelance+Electronics; ComponentLink18Description: https://cdn.amphenol-icc.com/media/wysiwyg/files/documentation/datasheet/inputoutput/io_usb_micro.pdf?src-supplier=Heilind+Electronics; ComponentLink18URL: https://cdn.amphenol-icc.com/media/wysiwyg/files/documentation/datasheet/inputoutput/io_usb_micro.pdf?src-supplier=Heilind+Electronics; Manufacturer Part Number: 10118192-0001LF; Pricing 1: 1=0,45000, 10=0,39700, 25=0,36240, 50=0,34120, 100=0,32700, 250=0,29856, 500=0,28434 (USD); RoHS: No; Stock 1: 75267; Supplier 1: Digi-Key; Supplier Part Number 1: 609-4613-1-ND; Library Name: burglar_alarm_system_Library.IntLib
X1 = CRYSTAL 32768kHZ: ABRACON - ABS05-32.768KHZ-T - Crystal, 32.768 kHz, SMD, 1.6mm x 1mm, 12.5 pF, 20 ppm, ABS05 Series; Designed By: İzzet Emre KARSAVURAN; ESR (Equivalent Series Resistance): 90kR; Frequency Tolerance: 20ppm; Load Capacitance: 12.5pF; Case/Package: SMD/SMT; Category: Crystals; Component Kind: Standard; ComponentLink1Description: https://datasheet.ciiva.com/23810/abs05-23810480.pdf?src-supplier=Newark; ComponentLink1URL: https://datasheet.ciiva.com/23810/abs05-23810480.pdf?src-supplier=Newark; ComponentLink2Description: https://datasheet.ciiva.com/6728/abs05-6728949.pdf?src-supplier=Digi-Key; ComponentLink2URL: https://datasheet.ciiva.com/6728/abs05-6728949.pdf?src-supplier=Digi-Key; ComponentLink3Description: https://datasheet.ciiva.com/6728/abs05-6728950.pdf?src-supplier=Digi-Key; ComponentLink3URL: https://datasheet.ciiva.com/6728/abs05-6728950.pdf?src-supplier=Digi-Key; ComponentLink4Description: https://datasheet.ciiva.com/16411/abs05-16411149.pdf?src-supplier=Future+Electronics; ComponentLink4URL: https://datasheet.ciiva.com/16411/abs05-16411149.pdf?src-supplier=Future+Electronics; Depth: 1mm; Height: 0.02inch; Lead Free: Lead Free; Length: 1.6mm; Manufacturer: Abracon; Manufacturer Part Number: ABS05-32.768KHZ-T; Max Operating Temperature: 85°C; Min Operating Temperature: -40°C; Mount: Surface Mount; Number of Pins: 2; Operating Frequency: 32.768 kHz; Package Quantity: 3000; Packaging: Tape and Reel; Pricing 1: 1=1,16000, 10=1,02500, 50=0,95760, 100=0,84630, 500=0,80180, 1000=0,66816 (USD); Radiation Hardening: No; REACH SVHC: No SVHC; RoHS: Yes; RoHS Compliant: Yes; Series: ABS05; Series Resistance: 90kR; Stock 1: 64299; Supplier 1: Digi-Key; Supplier Part Number 1: 535-11899-1-ND; Termination: SMD/SMT; Tolerance: 20ppm; Width: 0.039inch; Library Name: burglar_alarm_system_Library.IntLib
